# Altium schematic sheet 10_Misc.SchDoc(10_Misc) (continued)

## Library data of the parts
C154 C155 C161-C163 C166 C171 C185 C199 C200 = Cap_0402: Part Description: 0.10µF ±10% 16V X7R Ceramic Capacitor -55°C ~ 125°C Surface Mount, MLCC 0402 (1005 Metric) 0.039" L x 0.020" W (1.00mm x 0.50mm); Manufacturer Part Number 1: GRM155R71C104KA88D; Manufacturer: Murata Electronics; Type: General Purpose MLCC; Tolerance: 10 %; Temperature Coefficient / Code: +/- 15 %; Dielectric: X7R; Case Width: 0.5 mm; Case Length: 1 mm; Capacitance: 0.1 uF; Voltage Rating DC: 16 V; Value: 100nF, 16V; Termination Style: SMD/SMT; Supplier Part Number 1: 81-GRM155R71C104KA88; Supplier 1: Mouser; Standard Pack Qty: 10000; Series: GRM; RoHS - Mouser: Y; RoHS: RoHS Compliant; Reeling: True; Product Category: Low & Medium Capacitance SMD Ceramic Chip Capacitors; Product: General Type MLCCs; Packaging: Reel; Package / Case: 0402 (1005 metric); Operating Temperature Range: - 55 C to + 125 C; Minimum Order Quantity: 1; Minimum Operating Temperature: - 55 C; Maximum Operating Temperature: + 125 C; Manufacturer Part Number: GRM155R71C104KA88D; Component Kind: Standard; Category: Multilayer Ceramic Capacitors MLCC - SMD/SMT; Case Height: 0.5 mm; Case Code - mm: 1005; Case Code - in: 0402; Brand: Murata Electronics; Library Name: LimeMicroAltiumLib_schLib.SchLib
C156 C174 C175 C180 C181 C183 C186 C195 C201 = Cap_0603: Part Description: 0.10µF ±10% 16V X7R Ceramic Capacitor -55°C ~ 125°C Surface Mount, MLCC 0402 (1005 Metric) 0.039" L x 0.020" W (1.00mm x 0.50mm); Manufacturer Part Number 1: GRM155R71C104KA88D; Manufacturer: Murata Electronics; Type: General Purpose MLCC; Tolerance: 10 %; Temperature Coefficient / Code: +/- 15 %; Dielectric: X7R; Case Width: 0.5 mm; Case Length: 1 mm; Capacitance: 0.1 uF; Voltage Rating DC: 16 V; Value: 100nF, 16V; Termination Style: SMD/SMT; Supplier Part Number 1: 81-GRM155R71C104KA88; Supplier 1: Mouser; Standard Pack Qty: 10000; Series: GRM; RoHS - Mouser: Y; RoHS: RoHS Compliant; Reeling: True; Product Category: Low & Medium Capacitance SMD Ceramic Chip Capacitors; Product: General Type MLCCs; Packaging: Reel; Package / Case: 0402 (1005 metric); Operating Temperature Range: - 55 C to + 125 C; Minimum Order Quantity: 1; Minimum Operating Temperature: - 55 C; Maximum Operating Temperature: + 125 C; Component Kind: Standard; Category: Multilayer Ceramic Capacitors MLCC - SMD/SMT; Case Height: 0.5 mm; Case Code - mm: 1005; Case Code - in: 0402; Brand: Murata Electronics; Library Name: LimeFPGAboard_schLib.SchLib
  C156 C174 C175 C180 C181 C195 C201: Manufacturer Part Number: GRM155R71C104KA88D
C157 = Cap_0402: Part Description: CAP CER 0.018UF 16V X7R 0402; Manufacturer Part Number 1: CC0402KRX7R7BB183; Manufacturer: Yageo; Tolerance: ±10%; Temperature Coefficient: X7R; Capacitance: 0.018µF; Value: 18nF, 16V; Thickness (Max): 0.022" (0.55mm); Supplier Part Number 1: 311-1706-1-ND; Supplier 1: Digi-Key; Size / Dimension: 0.039" L x 0.020" W (1.00mm x 0.50mm); RoHS: RoHS Compliant; Part Status: Active; Packaging: Cut Tape (CT); Package / Case: 0402 (1005 Metric); Operating Temperature: -55°C ~ 125°C; Mounting Type: Surface Mount, MLCC; Component Kind: Standard; Category: Capacitors; Applications: General Purpose; Library Name: LimeMicroAltiumLib_schLib.SchLib
C158 C160 = Cap_0402: Part Description: 47pF ±1% 50V C0G, NP0 Ceramic Capacitor -55°C ~ 125°C Surface Mount, MLCC 0402 (1005 Metric) 0.039" L x 0.020" W (1.00mm x 0.50mm); Manufacturer Part Number 1: GRM1555C1H470FA01D; Manufacturer: TDK Corporation; Tolerance: ±1%; Temperature Coefficient: C0G, NP0; Value: 47pF, 50V; Thickness (Max): 0.022" (0.55mm); Supplier Part Number 1: 490-6241-1-ND; Supplier 1: Digi-Key; Size / Dimension: 0.039" L x 0.020" W (1.00mm x 0.50mm); RoHS: RoHS Compliant; Ratings: -; Packaging: Cut Tape (CT); Package / Case: 0402 (1005 Metric); Operating Temperature: -55°C ~ 125°C; Mounting Type: Surface Mount, MLCC; Lead Style: -; Lead Spacing: -; Height - Seated (Max): -; Features: -; Component Kind: Standard; Category: Capacitors; Capacitance: 47pF; Applications: General Purpose; Library Name: LimeMicroAltiumLib_schLib.SchLib
  C160: Voltage - Rated: 50V
C159 C164 C165 C194 = Cap_0402: Part Description: 1 µF ±10% 25V Ceramic Capacitor X5R 0402 (1005 Metric); Manufacturer Part Number 1: C1005X5R1E105K050BC; Manufacturer: TDK; Voltage Rating: 10; Product Range: TDK - C Series; Dielectric Characteristic: X6; Ceramic Capacitor Case: 0402 [1005 Metric]; Capacitance Tolerance: ± 10%; Capacitance: 1; Value: 1uF, 25V; Supplier Part Number 1: 445-9066-1-ND; Supplier 1: Digi-Key; RoHS: YES; MSL: -; Component Kind: Standard; Library Name: LimeMicroAltiumLib_schLib.SchLib
C167-C170 C172 C173 C192 = Cap_0402: Part Description: 100pF ±5% 50V C0G, NP0 Ceramic Capacitor -55°C ~ 125°C Surface Mount, MLCC 0402 (1005 Metric) 0.039" L x 0.020" W (1.00mm x 0.50mm); Manufacturer Part Number 1: CC0402JRNPO9BN101; Manufacturer: Yageo; Tolerance: ±5%; Temperature Coefficient: C0G, NP0; Value: 100pF, 50V; Thickness (Max): 0.022" (0.55mm); Supplier Part Number 1: 311-1024-1-ND; Supplier 1: Digi-Key; Size / Dimension: 0.039" L x 0.020" W (1.00mm x 0.50mm); RoHS: RoHS Compliant; Ratings: -; Packaging: Cut Tape (CT); Package / Case: 0402 (1005 Metric); Operating Temperature: -55°C ~ 125°C; Mounting Type: Surface Mount, MLCC; Lead Style: -; Lead Spacing: -; Height - Seated (Max): -; Features: -; Component Kind: Standard; Category: Capacitors; Capacitance: 100pF; Applications: General Purpose; Library Name: LimeMicroAltiumLib_schLib.SchLib
  C167 C172: Voltage - Rated: 50V
C176 C177 C188 C189 = Cap_0603: Part Description: 22µF ±20% 10V X5R Ceramic Capacitor -55°C ~ 85°C Surface Mount, MLCC 0603 (1608 Metric) 0.063" L x 0.031" W (1.60mm x 0.80mm); Manufacturer Part Number 1: C1608X5R1A226M080AC; Manufacturer: TDK Corporation; Type: Multilayer Ceramic Chip Capacitor; Tolerance: 20 %; Temperature Coefficient / Code: +/- 15 %; Brand: TDK; Voltage Rating DC: 10 V; Value: 22uF, 10V; Supplier Part Number 1: 810-C1608X5R1A226M; Supplier 1: Mouser; Package / Case: 0603 (1608 metric); Operating Temperature Range: - 55 C to + 85 C; Component Kind: Standard; Category: Multilayer Ceramic Capacitors MLCC - SMD/SMT; Case Code - mm: 1608; Case Code - in: 0603; Capacitance: 22 uF; Library Name: LimeFPGAboard_schLib.SchLib
C178 C179 C190 C191 C197 = Cap_0402: Part Description: 4.7µF ±20% 6.3V X5R Ceramic Capacitor -55°C ~ 85°C Surface Mount, MLCC 0402 (1005 Metric) 0.039" L x 0.020" W (1.00mm x 0.50mm); Manufacturer Part Number 1: GRM155R60J475ME87D; Manufacturer: Murata Electronics North America; Tolerance: ±20%; Temperature Coefficient: X5R; Capacitance: 4.7µF; Voltage - Rated: 6.3V; Value: 4.7uF, 6.3V; Thickness (Max): 0.022" (0.55mm); Supplier Part Number 1: 490-5408-1-ND; Supplier 1: Digi-Key; Size / Dimension: 0.039" L x 0.020" W (1.00mm x 0.50mm); RoHS: RoHS Compliant; Ratings: -; Packaging: Cut Tape (CT); Package / Case: 0402 (1005 Metric); Operating Temperature: -55°C ~ 85°C; Mounting Type: Surface Mount, MLCC; Lead Style: -; Lead Spacing: -; Height - Seated (Max): -; Features: Derating Recommended; Component Kind: Standard; Category: Capacitors; Applications: General Purpose; Library Name: LimeMicroAltiumLib_schLib.SchLib
C182 = Cap_0402: Part Description: 16 pF ±5% 50V Ceramic Capacitor C0G, NP0 0402 (1005 Metric); Manufacturer Part Number 1: CC0402JRNPO9BN160; Manufacturer: Yageo; Tolerance: 5%; Dielectric: C0G; Width: 0.02inch; Weight: 2.3E-05oz; Voltage Rating: 50V; Value: 16pF, 50V; Thickness: 0.022inch; Termination: SMD/SMT; Supplier Part Number 1: 311-1662-1-ND; Supplier 1: Digi-Key; Stock 1: 168271; Series: CC; RoHS Compliant: Yes; RoHS: Yes; Resistor Type: Ceramic; Pricing 1: 1=0,10000, 10=0,03700, 50=0,02020, 100=0,01640, 500=0,01174, 1000=0,00922, 2500=0,00838, 5000=0,00771 (USD); Packaging: Tape and Reel; Mount: Surface Mount; Min Operating Temperature: -55°C; Max Operating Temperature: 125°C; Length: 0.039inch; Lead Free: Lead Free; Depth: 0.5mm; ComponentLink2URL: http://www.yageo.com/documents/recent/UPY-GP_NP0_16V-to-50V_16.pdf?src-supplier=Digi-Key; ComponentLink2Description: http://www.yageo.com/documents/recent/UPY-GP_NP0_16V-to-50V_16.pdf?src-supplier=Digi-Key; ComponentLink1URL: http://www.mouser.com/ds/2/447/Yageo_UPY-GP_NP0_16V-to-50V_9-358835.pdf?src-supplier=Mouser; ComponentLink1Description: http://www.mouser.com/ds/2/447/Yageo_UPY-GP_NP0_16V-to-50V_9-358835.pdf?src-supplier=Mouser; Component Kind: Standard; Case Code (Metric): 1005; Case Code (Imperial): 0402; Case/Package: 0402; Capacitance: 16pF; Library Name: LimeMicroAltiumLib_schLib.SchLib
C184 = Cap_0402: Part Description: 1000pF ±10% 50V X7R Ceramic Capacitor -55°C ~ 125°C Surface Mount, MLCC 0402 (1005 Metric) 0.039" L x 0.020" W (1.00mm x 0.50mm); Manufacturer Part Number 1: GCM155R71H102KA37D; Manufacturer: Murata Electronics; Type: Automotive Ultra Small MLCC; Tolerance: 10 %; Temperature Coefficient / Code: +/- 15 %; Dielectric: X7R; Case Width: 0.5 mm; Case Length: 1 mm; Capacitance: 1000 pF; Voltage Rating DC: 50 V; Value: 1nF, 50V; Termination Style: SMD/SMT; Supplier Part Number 1: 81-GCM155R71H102KA7D; Supplier 1: Mouser; Standard Pack Qty: 10000; Series: GCM; RoHS - Mouser: Y; RoHS: RoHS Compliant; Reeling: True; Product Category: Automotive Ceramic Chip Capacitors; Product: Automotive MLCCs; Packaging: Reel; Package / Case: 0402 (1005 metric); Operating Temperature Range: - 55 C to + 125 C; Minimum Order Quantity: 1; Minimum Operating Temperature: - 55 C; Maximum Operating Temperature: + 125 C; Component Kind: Standard; Category: Multilayer Ceramic Capacitors MLCC - SMD/SMT; Case Height: 0.5 mm; Case Code - mm: 1005; Case Code - in: 0402; Brand: Murata Electronics; Library Name: LimeMicroAltiumLib_schLib.SchLib
C187 = Cap_0402: Part Description: 10000pF ±10% 50V Ceramic Capacitor X7R 0402 (1005 Metric); Manufacturer Part Number 1: GRM155R71H103KA88D; Manufacturer: Murata; Voltage: 25V; Tolerance: 10%; Material: Ceramic; Dielectric: X7R; Width: 0.02inch; Voltage Rating (DC): 50V; Voltage Rating: 50V; value: 10nF, 50V; Thickness: 0.022inch; Termination: SMD/SMT; Supplier Part Number 1: 490-4516-1-ND; Supplier 1: Digi-Key; Stock 1: 8941817; RoHS: Yes; REACH SVHC: No SVHC; Radiation Hardening: No; Pricing 1: 1=0,10000, 10=0,03800, 100=0,01690, 500=0,01208, 1000=0,00949, 2500=0,00862, 5000=0,00794 (USD); Packaging: Tape and Reel; Package Quantity: 1; Number of Pins: 2; Mount: Surface Mount; Min Operating Temperature: -55°C; Max Operating Temperature: 125°C; Length: 1mm; Lead Free: Lead Free; Height: 0.5mm; Depth: 0.5mm; Component Kind: Standard; Case Code (Metric): 1005; Case Code (Imperial): 0402; Case/Package: 0402; Capacitance: 10nF; Library Name: LimeFPGAboard_schLib.SchLib
C193 = Cap_0402: Part Description: 82 pF ±5% 50V Ceramic Capacitor CH 0402 (1005 Metric); Manufacturer Part Number 1: UMK105CH820JVHF; Manufacturer: Taiyo Yuden; Value: 82pF, 50V; Supplier Part Number 1: 587-5099-1-ND; Supplier 1: Digi-Key; Stock 1: 10720; RoHS: Yes; Pricing 1: 1=0,10000, 10=0,03000, 50=0,01640, 100=0,01340, 500=0,00954, 1000=0,00750, 2500=0,00682, 5000=0,00627 (USD); Component Kind: Standard; Library Name: LimeMicroAltiumLib_schLib.SchLib
C196 C198 = Cap_0603: Part Description: 10µF ±20% 6.3V X5R Ceramic Capacitor -55°C ~ 85°C Surface Mount, MLCC 0603 (1608 Metric) 0.063" L x 0.031" W (1.60mm x 0.80mm); Manufacturer Part Number 1: CC0603MRX5R5BB106; Manufacturer: Yageo; Tolerance: ±20%; Capacitance: 10µF; Value: 10uF, 6.3V; Supplier Part Number 1: 311-1448-2-ND; Supplier 1: Digi-Key; Package / Case: 0603 (1608 Metric); Component Kind: Standard; Category: Capacitors; Library Name: LimeFPGAboard_schLib.SchLib
ESD4 ESD5 = ESD8472: Part Description: TVS DIODE 5.3VWM 14VC X3DFN2; Manufacturer Part Number 1: ESD8472MUT5G; Manufacturer: ON Semiconductor; Voltage - Reverse Standoff (Typ): 5.3V (Max); Voltage - Clamping (Max) @ Ipp: 14V; Voltage - Breakdown (Min): 7V; Power Line Protection: No; Current - Peak Pulse (10/1000µs): 3A (8/20µs); Capacitance @ Frequency: 0.2pF @ 1MHz; Type: Zener; Supplier Part Number 1: ESD8472MUT5GOSCT-ND; Supplier Device Package: 2-X3DFN (0.62x0.32); Supplier 1: Digi-Key; Stock 1: 2295; RoHS: RoHS Compliant; Pricing 1: 1=0.39, 10=0.315, 100=0.2142, 500=0.16068, 1000=0.12051 (USD); Power - Peak Pulse: -; Part Status: Active; Packaging: Cut Tape (CT); Package / Case: 2-XFDFN; Operating Temperature: -55°C ~ 150°C (TJ); Mounting Type: Surface Mount; ComponentLink1URL: http://www.onsemi.com/pub/Collateral/ESD8472-D.PDF; ComponentLink1Description: http://www.onsemi.com/pub/Collateral/ESD8472-D.PDF; Component Kind: Standard; Category: Circuit Protection; Applications: Automotive, RF Antenna; Library Name: LimeMicroAltiumLib_schLib.SchLib
FR38-FR42 = Ferrite: Part Description: FERRITE BEAD 600 OHM 0201 1LN; Manufacturer Part Number 1: BK0603TS601-T; Manufacturer: Taiyo Yuden; Value: 600R@100MHz; Impedance @ Frequency: 600 Ohm @ 100MHz; Filter Type: Differential Mode - Single; DC Resistance (DCR): 750 mOhm Max; Current Rating: 270mA; Supplier Part Number 1: 587-3277-1-ND; Supplier 1: Digi-Key; Size / Dimension: 0.024" L x 0.012" W (0.60mm x 0.30mm); RoHS: RoHS Compliant; Packaging: Cut Tape (CT); Package / Case: 0201 (0603 Metric); Mounting Type: Surface Mount; Height (Max): 0.013" (0.33mm); Component Kind: Standard; Category: Filters; Library Name: LimeMicroAltiumLib_schLib.SchLib
FR44 FR45 = Ferrite: Part Description: FERRITE BEAD 220 OHM 0402 1LN; Manufacturer Part Number 1: MPZ1005S221ET000; Manufacturer: TDK Corporation; Impedance @ Frequency: 220 Ohm @ 100MHz; DC Resistance (DCR): 220 mOhm Max; Current Rating: 900mA; Value: 220R, 0.9A; Supplier Part Number 1: 445-9329-2-ND; Supplier 1: Digi-Key; Package / Case: 0402 (1005 Metric); Component Kind: Standard; Category: Filters; Library Name: LimeFPGAboard_schLib.SchLib
IC9 = LMK00101: Part Description: Clock Fanout Buffer (Distribution), Multiplexer, Translator IC 200MHz 32-WFQFN Exposed Pad; Manufacturer Part Number 1: LMK00101SQE/NOPB; Manufacturer: Texas Instruments; Voltage - Supply: 2.375 V ~ 3.45 V; Ratio - Input: 3:10; Output: LVCMOS, LVTTL; Number of Circuits: 1; Input: HCSL, LVCMOS, LVDS, LVPECL, LVTTL, SSTL, Crystal; Frequency - Max: 200MHz; Differential - Input: Yes/No; Type: Fanout Buffer (Distribution), Multiplexer, Translator; Supplier Part Number 1: 296-39036-1-ND; Supplier Device Package: 32-WQFN (5x5); Supplier 1: Digi-Key; RoHS: RoHS Compliant; Packaging: Cut Tape (CT); Package / Case: 32-WFQFN Exposed Pad; Operating Temperature: -40°C ~ 85°C; Mounting Type: Surface Mount; Component Kind: Standard; Category: Integrated Circuits (ICs); Library Name: LimeMicroAltiumLib_schLib.SchLib
IC10 = LM75: Part Description: SENSOR TEMPERATURE I2C 8HWSON; Manufacturer Part Number 1: LM75BTP,147; Manufacturer: NXP Semiconductors; Voltage - Supply: 2.8 V ~ 5.5 V; Test Condition: -25°C ~ 100°C (-55°C ~ 125°C); Sensor Type: Digital, Local; Sensing Temperature - Local: -55°C ~ 125°C; Resolution: 10 b; Output Type: I²C; Accuracy - Highest (Lowest): ±2°C (±3°C); Supplier Part Number 1: 568-9815-2-ND; Supplier Device Package: 8-HWSON (2x3); Supplier 1: Digi-Key; Sensing Temperature - Remote: -; RoHS: RoHS Compliant; Packaging: Tape & Reel (TR); Package / Case: 8-WFDFN Exposed Pad; Operating Temperature: -55°C ~ 125°C; Features: Output Switch, Programmable Limit, Shutdown Mode; Component Kind: Standard; Category: Sensors, Transducers; Library Name: LimeMicroAltiumLib_schLib.SchLib
IC11 = AD5601BKSZ-REEL7: Single 8-Bit nanoDAC with SPI Interface in LFCSP and SC70, 2.7 to 5.5 V, <100 uA, -40 to +125 degC, KS-6, Pb-Free, Reel; Part Description: 10 Bit Digital to Analog Converter 1 SC-70-6; Manufacturer Part Number 1: DAC6311IDCKT; Manufacturer: Texas Instruments; Spurious-free dynamic range (SFDR): 65dB; Signal to Noise Ratio (SNR): 81dB; Settling Time: 6us; Sampling Rate: 225KS/s; Resolution: 10b; Polarity: Unipolar; Output Type: Voltage; Operating Supply Voltage: 5V; Number of DAC Channels: 1; Number of Bits: 10; Nominal Supply Current: 110uA; Min Supply Voltage: 1.8V; Max Supply Voltage: 5.5V; Max Power Dissipation: 880uW; Integral Nonlinearity (INL): 0.5LSB; Width: 1.25mm; Termination: SMD/SMT; Supply Type: Single; Supplier Part Number 1: 296-23716-1-ND; Supplier 1: Digi-Key; Stock 1: 13410; RoHS Compliant: Yes; RoHS: Yes; REACH SVHC: No SVHC; Radiation Hardening: No; Pricing 1: 1=2,37000, 10=2,13100, 25=2,01000, 100=1,71250 (USD); Packaging: Tape and Reel; Package Quantity: 1; Number of Pins: 6; Number of D/A Converters: 1; Number of Converters: 1; Number of Channels: 1; Min Operating Temperature: -40°C; Max Operating Temperature: 125°C; Length: 2mm; Lead Free: Lead Free; Interface: SPI; Height: 0.9mm; Differential Nonlinearity: 0.5LSB; Conversion Rate: 225KS/s; Contact Plating: Gold; ComponentLink9URL: https://datasheet.ciiva.com/15146/getdatasheetpartid-213912-15146949.pdf?src-supplier=Verical; ComponentLink9Description: https://datasheet.ciiva.com/15146/getdatasheetpartid-213912-15146949.pdf?src-supplier=Verical; ComponentLink8URL: https://datasheet.ciiva.com/1368/dac5311-1368578.pdf?src-supplier=Digi-Key; ComponentLink8Description: https://datasheet.ciiva.com/1368/dac5311-1368578.pdf?src-supplier=Digi-Key; ComponentLink7URL: https://datasheet.ciiva.com/1368/dac5311-1368577.pdf?src-supplier=Digi-Key; ComponentLink7Description: https://datasheet.ciiva.com/1368/dac5311-1368577.pdf?src-supplier=Digi-Key; ComponentLink6URL: https://datasheet.ciiva.com/46391/1833965-46391478.pdf?src-supplier=Element14; ComponentLink6Description: https://datasheet.ciiva.com/46391/1833965-46391478.pdf?src-supplier=Element14; ComponentLink5URL: https://datasheet.ciiva.com/1503/dac5311-1503186.pdf?src-supplier=Farnell; ComponentLink5Description: https://datasheet.ciiva.com/1503/dac5311-1503186.pdf?src-supplier=Farnell; ComponentLink4URL: https://datasheet.ciiva.com/1494/1833965-1494412.pdf?src-supplier=Element14; ComponentLink4Description: https://datasheet.ciiva.com/1494/1833965-1494412.pdf?src-supplier=Element14; ComponentLink3URL: https://datasheet.ciiva.com/4779/sbas442a-125649-4779152.pdf?src-supplier=Mouser; ComponentLink3Description: https://datasheet.ciiva.com/4779/sbas442a-125649-4779152.pdf?src-supplier=Mouser; ComponentLink2URL: https://datasheet.ciiva.com/1362/dac7311-1362880.pdf?src-supplier=Digi-Key; ComponentLink2Description: https://datasheet.ciiva.com/1362/dac7311-1362880.pdf?src-supplier=Digi-Key; ComponentLink1URL: http://www.ti.com/lit/ds/symlink/dac5311.pdf; ComponentLink1Description: http://www.ti.com/lit/ds/symlink/dac5311.pdf; Component Kind: Standard; Case/Package: SC; Library Name: LimeMicroAltiumLib_schLib.SchLib
IC12 = M24128-DFMC6TG: Part Description: EEPROM Memory IC 128Kb (16K x 8) I²C 1MHz 450ns 8-UFDFPN (2x3); Manufacturer: STMicroelectronics; Write Cycle Time - Word, Page: 5ms; Voltage - Supply: 1.7 V ~ 5.5 V; Memory Type: Non-Volatile; Memory Size: 128Kb (16K x 8); Memory Interface: I²C; Memory Format: EEPROM; Technology: EEPROM; Supplier Part Number 1: 497-15739-1-ND; Supplier Device Package: 8-UFDFPN (2x3); Supplier 1: Digi-Key; Stock 1: 5894; RoHS: RoHS Compliant; Pricing 1: 1=0.35, 10=0.348, 100=0.2854, 500=0.27748, 1000=0.27004 (USD); Part Status: Active; Packaging: Cut Tape (CT); Package / Case: 8-UFDFN Exposed Pad; Operating Temperature: -40°C ~ 85°C (TA); Mounting Type: Surface Mount; Manufacturer Part Number 1: M24128-DFMC6TG; ComponentLink1URL: http://www.st.com/content/ccc/resource/technical/document/datasheet/59/05/c9/5b/7b/41/48/b6/CD00259167.pdf/files/CD00259167.pdf/jcr:content/translations/en.CD00259167.pdf; ComponentLink1Description: http://www.st.com/content/ccc/resource/technical/document/datasheet/59/05/c9/5b/7b/41/48/b6/CD00259167.pdf/files/CD00259167.pdf/jcr:content/translations/en.CD00259167.pdf; Component Kind: Standard; Category: Integrated Circuits (ICs); Library Name: LimeMicroAltiumLib_schLib.SchLib
IC13 IC18 = LD39100PUR: Part Description: Linear Voltage Regulator IC Positive Adjustable Output 0.8 V ~ 4.5 V 1A 6-DFN (3x3); Manufacturer: STMicroelectronics; Voltage Dropout (Max): 0.4V @ 1A; Voltage - Output (Min/Fixed): 0.8V; Voltage - Output (Max): 4.6V; Voltage - Input (Max): 5.5V; PSRR: 70dB ~ 65dB (1kHz ~ 10kHz); Protection Features: Over Current, Over Temperature, Short Circuit; Output Type: Adjustable; Output Configuration: Positive; Current - Supply: 50µA ~ 300µA; Current - Output: 1A; Control Features: Enable, Power Good; Supplier Part Number 1: 497-11013-1-ND; Supplier Device Package: 6-DFN (3x3); Supplier 1: Digi-Key; Stock 1: 27970; RoHS: RoHS Compliant; Pricing 1: 1=0.85, 10=0.76, 100=0.5923, 500=0.48926, 1000=0.38625 (USD); Part Status: Active; Packaging: Cut Tape (CT); Package / Case: 6-VDFN Exposed Pad; Operating Temperature: -40°C ~ 125°C; Mounting Type: Surface Mount; Manufacturer Part Number 1: LD39100PUR; ComponentLink1URL: http://www.st.com/content/ccc/resource/technical/document/datasheet/72/02/e5/ee/df/36/40/5e/CD00233788.pdf/files/CD00233788.pdf/jcr:content/translations/en.CD00233788.pdf; ComponentLink1Description: http://www.st.com/content/ccc/resource/technical/document/datasheet/72/02/e5/ee/df/36/40/5e/CD00233788.pdf/files/CD00233788.pdf/jcr:content/translations/en.CD00233788.pdf; Component Kind: Standard; Category: Integrated Circuits (ICs); Library Name: LimeMicroAltiumLib_schLib.SchLib
IC14 IC19 = LMZ20501SILR_DC-DC: Part Description: Non-Isolated PoL Module DC DC Converter 1 Output 0.8 ~ 3.6V 1A 2.7V - 5.5V Input; Manufacturer: Texas Instruments; Voltage - Output 1: 3.6V; Switching Frequency: 3MHz; Quiescent Current: 72nA; Output Type: Adjustable; Operating Supply Voltage: 5.5V; Number of Outputs: 1; Min Input Voltage: 2.7V; Max Power Dissipation: 4W; Max Output Current: 1A; Efficiency: 93%; Width: 0.14inch; Supplier Part Number 1: 296-40328-1-ND; Supplier 1: Digi-Key; Stock 1: 8106; RoHS Compliant: Yes; RoHS: Yes; Pricing 1: 1=4,48000, 10=4,02000, 25=3,80040, 100=3,29350, 250=3,12464, 500=2,80372, 1000=2,54942 (USD); Packaging: Tape and Reel; Package Quantity: 3000; Output Voltage: 3.6V; Number of Pins: 8; Mount: Surface Mount; Min Operating Temperature: -40°C; Max Operating Temperature: 125°C; Max Input Voltage: 5.5V; Manufacturer Part Number 1: LMZ20501SILR; Length: 0.14inch; Lead Free: Lead Free; Height: 0.07inch; Features: UVLO,OCP,OTP,SCP; ComponentLink4URL: http://www.ti.com/general/docs/lit/getliterature.tsp?genericPartNumber=LMZ20501&&fileType=pdf&src-supplier=Avnet; ComponentLink4Description: http://www.ti.com/general/docs/lit/getliterature.tsp?genericPartNumber=LMZ20501&&fileType=pdf&src-supplier=Avnet; ComponentLink3URL: http://www.ti.com/lit/gpn/lmz20501?src-supplier=Mouser; ComponentLink3Description: http://www.ti.com/lit/gpn/lmz20501?src-supplier=Mouser; ComponentLink2URL: http://www.ti.com/lit/ds/symlink/lmz20501.pdf?src-supplier=Digi-Key; ComponentLink2Description: http://www.ti.com/lit/ds/symlink/lmz20501.pdf?src-supplier=Digi-Key; ComponentLink1URL: http://www.ti.com/lit/pdf/snaa214?src-supplier=Digi-Key; ComponentLink1Description: http://www.ti.com/lit/pdf/snaa214?src-supplier=Digi-Key; Component Kind: Standard; Case/Package: SMD/SMT; Library Name: LimeMicroAltiumLib_schLib_r04.SchLib
IC15 = M25P40_with_pad: Part Description: FLASH - NOR Memory IC 128Mb (16M x 8) SPI - Quad I/O, QPI, DTR 133 MHz 8-SOIC; Manufacturer: Winbond; Memory Type: FLASH,NOR; Interface: SPI,Serial; Frequency: 104MHz; Supplier Part Number 1: W25Q128JVSIQ-ND; Supplier 1: Digi-Key; Stock 1: 7729; RoHS Compliant: Yes; RoHS: Yes; Pricing 1: 1=1,88000, 10=1,71400, 25=1,66760, 50=1,65880, 100=1,48280, 250=1,47852, 500=1,45618, 1000=1,39437, 5000=1,29820 (USD); Packaging: Tray; Min Operating Temperature: -40°C; Max Operating Temperature: 85°C; Manufacturer Part Number 1: W25Q128JVSIQ; ComponentLink1URL: http://www.winbond.com/resource-files/w25q128jv%20revf%2003272018%20plus.pdf?src-supplier=Digi-Key; ComponentLink1Description: http://www.winbond.com/resource-files/w25q128jv%20revf%2003272018%20plus.pdf?src-supplier=Digi-Key; Component Kind: Standard; Case/Package: SOIC; Library Name: LimeMicroAltiumLib_schLib_r03.SchLib
IC16 = AD5662: Part Description: IC DAC 16BIT BUFF V-OUT SOT23-8; Manufacturer Part Number 1: AD5662BRJZ-2REEL7; Manufacturer: Analog Devices Inc.; Voltage - Supply, Digital: 2.7 V ~ 5.5 V; Settling Time: 10µs; Reference Type: External; Output Type: Voltage - Buffered; Number of D/A Converters: 1; Number of Bits: 16; INL/DNL (LSB): ±8, ±1 (Max); Differential Output: No; Data Interface: SPI, DSP; Architecture: String DAC; Voltage - Supply, Analog: 2.7 V ~ 5.5 V; Supplier Part Number 1: AD5662BRJZ-2REEL7CT-ND; Supplier Device Package: SOT-23-8; Supplier 1: Digi-Key; Stock 1: 2784; RoHS: RoHS Compliant; Pricing 1: 1=7.55, 10=6.821, 100=5.6469, 500=4.91722, 1000=4.28274 (USD); Part Status: Active; Packaging: Cut Tape (CT); Package / Case: SOT-23-8; Operating Temperature: -40°C ~ 125°C; ComponentLink1URL: http://www.analog.com/media/en/technical-documentation/data-sheets/AD5662.pdf; ComponentLink1Description: http://www.analog.com/media/en/technical-documentation/data-sheets/AD5662.pdf; Component Kind: Standard; Category: Integrated Circuits (ICs); Library Name: LimeMicroAltiumLib_schLib.SchLib
IC17 = VOLTAGE_REFERENCE_UNIVERSAL: Manufacturer Part Number 1: LM4040C30FTA; Manufacturer: Diodes Inc.; Voltage Tolerance: 0.5%; Temperature Coefficient: 100ppm/°C; Reference Voltage: 3V; Reference Type: Shunt; Output Type: Fixed; Output Current: 15mA; Number of Outputs: 1; Min Output Voltage: 2.985V; Max Output Voltage: 3.015V; Cathode Current: 70µA; Width: 1.3mm; Weight: 7.994566mg; Value: LM4040; Tolerance: 0.5%; Terminal Pitch: 950µm; Temperature Grade: Automotive; Supplier Part Number 1: LM4040C30FTADICT-ND; Supplier 1: DigiKey; Stock 1: 2442; Schedule B: 8542390000, 8542390000|8542390000|8542390000|8542390000|8542390000; RoHS: Compliant; REACH SVHC: Unaffected; Radiation Hardening: No; Pricing 1: 1=0.57, 10=0.403, 25=0.36, 50=0.3342, 100=0.313 (USD); Output Voltage: 3V; Number of Terminals: 3; Number of Pins: 3; Number of Circuits: 1; Number of Channels: 1; Nominal Output Voltage: 3V; Mount: Surface Mount; Min Operating Temperature: -40°C; Max Output Current: 15mA; Max Operating Temperature: 125°C; Lifecycle Status: Production; Length: 2.92mm; Lead Free: Lead Free; Introduction Date: 2008-01-28; Height - Seated (Max): 1.12mm; Height: 1.02mm; Harmonized Tariff Schedule (HTS) Code: 8542.39.00.60; Export Control Classification Number (ECCN) Code: EAR99; Current Rating: 15mA; Country of Origin: Mainland China; ComponentLink6URL: https://datasheet.octopart.com:443/LM4040C30FTA-Diodes-Inc.-datasheet-8325591.pdf?src-supplier=Future+Electronics; ComponentLink6Description: https://datasheet.octopart.com:443/LM4040C30FTA-Diodes-Inc.-datasheet-8325591.pdf?src-supplier=Future+Electronics; ComponentLink5URL: https://sigma.octopart.com:443/138706058/technical_drawing/Diodes-Inc.-LM4040C30FTA.pdf?src-supplier=Diodes+Inc+SCT; ComponentLink5Description: https://sigma.octopart.com:443/138706058/technical_drawing/Diodes-Inc.-LM4040C30FTA.pdf?src-supplier=Diodes+Inc+SCT; ComponentLink4URL: https://datasheet.octopart.com:443/LM4040C30FTA-Diodes-Inc.-datasheet-11728326.pdf?src-supplier=_legacy+Avnet; ComponentLink4Description: https://datasheet.octopart.com:443/LM4040C30FTA-Diodes-Inc.-datasheet-11728326.pdf?src-supplier=_legacy+Avnet; ComponentLink3URL: https://datasheet.octopart.com:443/LM4040C30FTA-Diodes-Inc.-datasheet-79468892.pdf?src-supplier=Augswan; ComponentLink3Description: https://datasheet.octopart.com:443/LM4040C30FTA-Diodes-Inc.-datasheet-79468892.pdf?src-supplier=Augswan; ComponentLink2URL: https://datasheet.octopart.com:443/LM4040C30FTA-Zetex-datasheet-619181.pdf?src-supplier=IHS; ComponentLink2Description: https://datasheet.octopart.com:443/LM4040C30FTA-Zetex-datasheet-619181.pdf?src-supplier=IHS; ComponentLink1URL: https://datasheet.octopart.com:443/LM4040C30FTA-Diodes-Inc.-datasheet-141402645.pdf?src-supplier=IHS; ComponentLink1Description: https://datasheet.octopart.com:443/LM4040C30FTA-Diodes-Inc.-datasheet-141402645.pdf?src-supplier=IHS; Component Kind: Standard; China RoHS: Compliant; Case/Package: TSSOP; Library Name: LimeMicroAltiumLib_schLib_r05.SchLib
IC20 = ATECC508A_authentication_IC: Part Description: Authentication Chip IC Networking and Communications 8-UDFN (2x3); Manufacturer Part Number 1: ATECC508A-MAHDA-S; Manufacturer: Microchip; Supplier Part Number 1: ATECC508A-MAHDA-SDKR-ND; Supplier 1: Digi-Key; Stock 1: 27941; Pricing 1: 1=0.73, 25=0.7064 (USD); Component Kind: Standard; Library Name: LimeMicroAltiumLib_schLib_r01.SchLib
J7 J8 = RF_IF_connector: CONN UMC RCPT STR 50 OHM SMD; Part Description: Ultra Miniature Coaxial Connector Receptacle, Male Pin 50 Ohm Surface Mount Solder; Manufacturer Part Number 1: 0734120110; Manufacturer: Molex, LLC; Voltage Rating: 60V; Shield Termination: Solder; Impedance: 50 Ohm; Frequency - Max: 6GHz; Dielectric Material: Liquid Crystal Polymer (LCP); Connector Type: Jack, Male Pin; Connector Style: Ultra Miniature Coaxial; Center Contact Plating: Gold; Center Contact Material: Brass; Body Material: Phosphor Bronze; Body Finish: Nickel; Supplier Part Number 1: WM5587CT-ND; Supplier 1: Digi-Key; RoHS: RoHS Compliant; Packaging: Cut Tape (CT); Operating Temperature: -40°C ~ 90°C; Mounting Type: Surface Mount; Ingress Protection: -; Housing Color: Silver; Features: -; Fastening Type: Snap-On; Contact Termination: Solder; Component Kind: Standard; Category: Connectors, Interconnects; Cable Group: -; Library Name: LimeMicroAltiumLib_schLib.SchLib
J9 = Jumper: Part Description: 2 Positions Header, Unshrouded Connector 0.100" (2.54mm) Through Hole Gold; Manufacturer Part Number 1: 961102-6404-AR; Manufacturer: 3M; Connector Type: Header, Unshrouded; Supplier Part Number 1: 3M9447-ND; Supplier 1: Digi-Key; Mounting Type: Through Hole; Contact Type: Male Pin; Contact Finish: Gold; Component Kind: Standard; Color: Black; Category: Connectors, Interconnects; Library Name: LimeFPGAboard_schLib.SchLib
LED1-LED3 = LED_green_red_SMD: Part Description: LED GREEN/RED DIFFUSED 0603 SMD; Manufacturer Part Number 1: HSMF-C165; Manufacturer: Avago Technologies US Inc.; Wavelength - Peak: 570nm Green, 636nm Red; Wavelength - Dominant: 572nm Green, 621nm Red; Voltage - Forward (Vf) (Typ): 2.2V Green, 1.95V Red; Viewing Angle: 120°; Millicandela Rating: 15mcd Green, 10mcd Red; Lens Transparency: Diffused; Lens Style/Size: Rectangle with Flat Top, 1.60mm x 0.80mm; Lens Color: White; Current - Test: 20mA; Color: Green, Red; Supplier Part Number 1: 516-1419-1-ND; Supplier 1: Digi-Key; Size / Dimension: 1.60mm L x 0.80mm W; RoHS: RoHS Compliant; Packaging: Cut Tape (CT); Package / Case: 0603 (1608 Metric); Mounting Type: Surface Mount; Height (Max): 0.50mm; Component Kind: Standard; Category: Optoelectronics; Library Name: LimeMicroAltiumLib_schLib.SchLib
R61 R65 R66 R69 R78 R90 R94 R96 R98 R100-R102 = Res_0402: Part Description: RES SMD 0.0OHM JUMPER 1/16W 0402; Manufacturer Part Number 1: RC0402JR-070RL; Manufacturer: Yageo; Tolerance: Jumper; Resistance (Ohms): 0.0; Power (Watts): 0.063W, 1/16W; Value: 0R; Temperature Coefficient: -; Supplier Part Number 1: 311-0.0JRTR-ND; Supplier Device Package: 0402; Supplier 1: Digi-Key; Size / Dimension: 0.039" L x 0.020" W (1.00mm x 0.50mm); RoHS: RoHS Compliant; Packaging: Tape & Reel (TR); Package / Case: 0402 (1005 Metric); Operating Temperature: -55°C ~ 155°C; Number of Terminations: 2; Height: 0.016" (0.40mm); Features: Moisture Resistant; Composition: Thick Film; Component Kind: Standard; Category: Resistors
  R61 R66 R78 R90 R94 R96 R100 R102: Library Name: LimeMicroAltiumLib_schLib.SchLib
  R65 R69 R98 R101: Library Name: LimeFPGAboard_schLib.SchLib
R62 R71 R73 R74 R77 R83 R84 R86 = Res_0402: Part Description: RES SMD 10K OHM 1% 1/16W 0402; Manufacturer Part Number 1: RC0402FR-0710KL; Manufacturer: Yageo; Temperature Coefficient: ±100ppm/°C; Power (Watts): 0.063W, 1/16W; Value: 10k, 1%; Tolerance: ±1%; Supplier Part Number 1: 311-10.0KLRTR-ND; Supplier Device Package: 0402; Supplier 1: Digi-Key; Size / Dimension: 0.039" L x 0.020" W (1.00mm x 0.50mm); RoHS: RoHS Compliant; Resistance (Ohms): 10k; Packaging: Tape & Reel (TR); Package / Case: 0402 (1005 Metric); Operating Temperature: -55°C ~ 155°C; Number of Terminations: 2; Height: 0.016" (0.40mm); Features: Moisture Resistant; Composition: Thick Film; Component Kind: Standard; Category: Resistors; Library Name: LimeMicroAltiumLib_schLib.SchLib
R63 R85 R87 R92 R95 R97 R99 = Res_0402: Part Description: RES SMD 100 OHM 1% 1/16W 0402; Manufacturer Part Number 1: RMCF0402FT100R; Manufacturer: Stackpole Electronics Inc.; Temperature Coefficient: ±100ppm/°C; Resistance (Ohms): 100; Power (Watts): 0.063W, 1/16W; Value: 100R, 1%; Tolerance: ±1%; Supplier Part Number 1: RMCF0402FT100RDKR-ND; Supplier Device Package: 0402; Supplier 1: Digi-Key; Size / Dimension: 0.039" L x 0.020" W (1.00mm x 0.50mm); RoHS: RoHS Compliant; Packaging: Digi-Reel®; Package / Case: 0402 (1005 Metric); Operating Temperature: -55°C ~ 155°C; Number of Terminations: 2; Height: 0.016" (0.40mm); Features: -; Composition: Thick Film; Component Kind: Standard; Category: Resistors; Library Name: LimeMicroAltiumLib_schLib.SchLib
R64 = Res_0402: Part Description: RES SMD 22 OHM 1% 1/16W 0402; Manufacturer Part Number 1: RC0402FR-0722RL; Manufacturer: Yageo; Tolerance: ±1%; Temperature Coefficient: ±100ppm/°C; Resistance (Ohms): 22; Value: 22R; Supplier Part Number 1: 311-22.0LRTR-ND; Supplier Device Package: 0402; Supplier 1: Digi-Key; Composition: Thick Film; Component Kind: Standard; Category: Resistors; Library Name: LimeFPGAboard_schLib.SchLib
R67 R103 = Res_0402: Part Description: RES SMD 49.9 OHM 1% 1/16W 0402; Manufacturer Part Number 1: RC1005F49R9CS; Manufacturer: Samsung Electro-Mechanics America, Inc.; Temperature Coefficient: ±100ppm/°C; Resistance (Ohms): 49.9; Power (Watts): 0.063W, 1/16W; Value: 49.9R, 1%; Tolerance: ±1%; Supplier Part Number 1: 1276-3469-6-ND; Supplier Device Package: 0402 (1005 Metric); Supplier 1: Digi-Key; Size / Dimension: 0.039" L x 0.020" W (1.00mm x 0.50mm); RoHS: RoHS Compliant; Packaging: Digi-Reel®; Package / Case: 0402 (1005 Metric); Operating Temperature: -55°C ~ 155°C; Number of Terminations: 2; Height: 0.016" (0.40mm); Features: -; Composition: Thick Film; Component Kind: Standard; Category: Resistors; Library Name: LimeMicroAltiumLib_schLib.SchLib
R68 R70 R72 R75 R76 R81 = Res_0402: Part Description: RES SMD 1K OHM 1% 1/16W 0402; Manufacturer Part Number 1: RMCF0402FT1K00; Manufacturer: Stackpole Electronics Inc; Temperature Coefficient: ±100ppm/°C; Power (Watts): 0.063W, 1/16W; Value: 1k, 1%; Tolerance: ±1%; Supplier Part Number 1: RMCF0402FT1K00TR-ND; Supplier Device Package: 0402; Supplier 1: Digi-Key; Size / Dimension: 0.039" L x 0.020" W (1.00mm x 0.50mm); RoHS: RoHS Compliant; Resistance (Ohms): 1k; Package / Case: 0402 (1005 Metric); Operating Temperature: -55°C ~ 155°C; Number of Terminations: 2; Height: 0.016" (0.40mm); Composition: Thick Film; Component Kind: Standard; Category: Resistors; Library Name: LimeMicroAltiumLib_schLib.SchLib
  R68 R70 R72 R75 R76: Packaging: Cut Tape (CT); Features: Moisture Resistant
  R81: Packaging: Tape & Reel (TR); Features: -
R79 = Res_0402: Part Description: 80.6 kOhms ±1% 0.063W, 1/16W Chip Resistor 0402 (1005 Metric) Automotive AEC-Q200 Thick Film; Manufacturer Part Number 1: RMCF0402FT80K6; Manufacturer: Stackpole Electronics; Temperature Coefficient: 100ppm/°C; Resistance: 80.6kR; Max Power Dissipation: 63mW; Width: 0.02inch; Value: 80.6k, 1%; Tolerance: 1%; Supplier Part Number 1: RMCF0402FT80K6CT-ND; Supplier 1: Digi-Key; Stock 1: 329079; Series: RMCF; RoHS Compliant: Yes; RoHS: Yes; Resistor Type: Thick Film; Radiation Hardening: No; Pricing 1: 1=0,10000, 10=0,01300, 100=0,00550, 1000=0,00246, 2500=0,00213, 5000=0,00176 (USD); Packaging: Tape and Reel; Number of Terminations: 2; Mount: Surface Mount; Min Operating Temperature: -55°C; Max Operating Temperature: 155°C; Length: 0.039inch; Lead Free: Lead Free; Height: 0.016inch; Features: Automotive AEC-Q200; Contact Plating: Tin,Matte; ComponentLink3URL: https://www.seielect.com/Catalog/SEI-RMCF_RMCP.pdf?src-supplier=Digi-Key; ComponentLink3Description: https://www.seielect.com/Catalog/SEI-RMCF_RMCP.pdf?src-supplier=Digi-Key; ComponentLink2URL: https://www.seielect.com/catalog/SEI-RMCF_RMCP.pdf?src-supplier=Avnet; ComponentLink2Description: https://www.seielect.com/catalog/SEI-RMCF_RMCP.pdf?src-supplier=Avnet; ComponentLink1URL: https://www.seielect.com/catalog/SEI-Packaging.pdf?src-supplier=Digi-Key; ComponentLink1Description: https://www.seielect.com/catalog/SEI-Packaging.pdf?src-supplier=Digi-Key; Component Kind: Standard; Case Code (Metric): 1005; Case Code (Imperial): 0402; Case/Package: 0402; Library Name: LimeMicroAltiumLib_schLib.SchLib
R80 = Res_0402: Part Description: 27 kOhms ±1% 0.063W, 1/16W Chip Resistor 0402 (1005 Metric) Moisture Resistant Thick Film; Manufacturer Part Number 1: RC0402FR-0727KL; Manufacturer: Yageo; Voltage Rating: 50V; Temperature Coefficient: 100ppm/°C; Resistance: 27kR; Power Rating: 63mW; Width: 0.02inch; Value: 27k, 1%; Termination: SMD/SMT; Technology: THICK FILM; Supplier Part Number 1: 311-27.0KLRCT-ND; Supplier 1: Digi-Key; Stock 1: 1102573; Size Code: 0402; Series: RC; RoHS Compliant: Yes; RoHS: Yes; Resistor Type: Thick Film; Resistance Tolerance: 1%; REACH SVHC: Unknown; Pricing 1: 1=0,10000, 10=0,01600, 100=0,00660, 1000=0,00299, 2500=0,00259, 5000=0,00214 (USD); Packaging: Tape and Reel; Package Quantity: 10000; Number of Terminations: 2; Min Operating Temperature: -55°C; Military Standard: Not; Max Power Dissipation: 63mW; Max Operating Temperature: 155°C; Length: 1mm; Lead Free: Lead Free; Height: 0.016inch; Features: Moisture Resistant; Depth: 0.5mm; Construction: Rectangular; ComponentLink1URL: http://www.yageo.com/documents/recent/PYu-RC_Group_51_RoHS_L_7.pdf; ComponentLink1Description: http://www.yageo.com/documents/recent/PYu-RC_Group_51_RoHS_L_7.pdf; Component Kind: Standard; Case Code (Metric): 1005; Case Code (Imperial): 0402; Case/Package: 0402; Library Name: LimeFPGAboard_schLib.SchLib
R82 = Res_0402: Part Description: 40.2 kOhms ±1% 0.063W, 1/16W Chip Resistor 0402 (1005 Metric) Moisture Resistant Thick Film; Manufacturer Part Number 1: RC0402FR-0740K2L; Manufacturer: Yageo; Voltage Rating (DC): 50V; Temperature Coefficient: 100ppm/°C; Resistance: 40.2kR; Power Rating: 62.5mW; Max Power Dissipation: 63mW; Width: 0.02inch; Voltage Rating: 50V; Value: 40.2k, 1%; Tolerance: 1%; Termination: SMD/SMT; Supplier Part Number 1: 311-40.2KLRCT-ND; Supplier 1: Digi-Key; Stock 1: 2895359; Size Code: 0402; Series: RC; RoHS Compliant: Yes; RoHS: Yes; Resistor Type: Thick Film; Pricing 1: 1=0,10000, 10=0,01500, 100=0,00600, 1000=0,00267, 2500=0,00232, 5000=0,00192 (USD); Packaging: Tape and Reel; Package Quantity: 1; Number of Terminations: 2; Min Operating Temperature: -55°C; Military Standard: Not; Max Operating Temperature: 155°C; Length: 0.039inch; Lead Free: Lead Free; Height: 0.016inch; Features: Moisture Resistant; Depth: 0.5mm; Contact Plating: Tin,Matte; Construction: Rectangular; ComponentLink6URL: https://datasheet.ciiva.com/16744/pyu-rc0402-51-rohs-l-6-r-16744286.pdf?src-supplier=Future+Electronics; ComponentLink6Description: https://datasheet.ciiva.com/16744/pyu-rc0402-51-rohs-l-6-r-16744286.pdf?src-supplier=Future+Electronics; ComponentLink5URL: https://datasheet.ciiva.com/8889/pyu-rc0402-51-rohs-l-6-8889263.pdf?src-supplier=Avnet; ComponentLink5Description: https://datasheet.ciiva.com/8889/pyu-rc0402-51-rohs-l-6-8889263.pdf?src-supplier=Avnet; ComponentLink4URL: https://datasheet.ciiva.com/5557/pyu-rc0402-51-rohs-l-6-r-5557981.pdf?src-supplier=Mouser; ComponentLink4Description: https://datasheet.ciiva.com/5557/pyu-rc0402-51-rohs-l-6-r-5557981.pdf?src-supplier=Mouser; ComponentLink3URL: https://datasheet.ciiva.com/2453/pyu-r-int-thick-7-2453436.pdf?src-supplier=Digi-Key; ComponentLink3Description: https://datasheet.ciiva.com/2453/pyu-r-int-thick-7-2453436.pdf?src-supplier=Digi-Key; ComponentLink2URL: https://datasheet.ciiva.com/2453/pyu-r-int-thick-7-2453438.pdf?src-supplier=Digi-Key; ComponentLink2Description: https://datasheet.ciiva.com/2453/pyu-r-int-thick-7-2453438.pdf?src-supplier=Digi-Key; ComponentLink1URL: https://datasheet.ciiva.com/2453/pyu-r-int-thick-7-2453437.pdf?src-supplier=Digi-Key; ComponentLink1Description: https://datasheet.ciiva.com/2453/pyu-r-int-thick-7-2453437.pdf?src-supplier=Digi-Key; Component Kind: Standard; Case Code (Metric): 1005; Case Code (Imperial): 0402; Case/Package: 0402; Library Name: LimeMicroAltiumLib_schLib.SchLib
R88 = Res_0402: Part Description: 237 kOhms ±1% 0.063W, 1/16W Chip Resistor 0402 (1005 Metric) Moisture Resistant Thick Film; Manufacturer Part Number 1: RC0402FR-07237KL; Manufacturer: Yageo; Voltage Rating: 50V; Temperature Coefficient: 100ppm/°C; Resistance: 237kR; Power Rating: 62.5mW; Max Power Dissipation: 63mW; Width: 0.5mm; Value: 237k, 1%; Tolerance: 1%; Termination: SMD/SMT; Supplier Part Number 1: YAG3064CT-ND; Supplier 1: Digi-Key; Stock 1: 306971; Size Code: 0402; Series: RC; RoHS Compliant: Yes; RoHS: Yes; Resistor Type: Thick Film; Pricing 1: 1=0,10000, 10=0,01500, 100=0,00600, 1000=0,00267, 2500=0,00232, 5000=0,00192 (USD); Packaging: Tape and Reel; Number of Terminations: 2; Min Operating Temperature: -55°C; Military Standard: Not; Max Operating Temperature: 155°C; Length: 1mm; Lead Free: Lead Free; Height: 0.016inch; Features: Moisture Resistant; Depth: 0.5mm; Contact Plating: Tin,Matte; Construction: Rectangular; ComponentLink1URL: https://datasheet.ciiva.com/17419/pyu-rc0402-51-rohs-l-6-r-17419479.pdf?src-supplier=Future+Electronics; ComponentLink1Description: https://datasheet.ciiva.com/17419/pyu-rc0402-51-rohs-l-6-r-17419479.pdf?src-supplier=Future+Electronics; Component Kind: Standard; Case Code (Metric): 1005; Case Code (Imperial): 0402; Case/Package: 0402; Library Name: LimeMicroAltiumLib_schLib.SchLib
R89 R93 = Res_0402: Part Description: ±1% 0.063W, 1/16W Chip Resistor 0402 (1005 Metric) Moisture Resistant Thick Film; Manufacturer Part Number 1: RC0402FR-074K7L; Manufacturer: Yageo; Temperature Coefficient: ±100ppm/°C; Power (Watts): 0.063W, 1/16W; Value: 4K7, 1%; Tolerance: ±1%; Supplier Part Number 1: 311-4.7KLRCT-ND; Supplier Device Package: 0402; Supplier 1: Digi-Key; Stock 1: 4788231; Size / Dimension: 0.039" L x 0.020" W (1.00mm x 0.50mm); RoHS: RoHS Compliant; Pricing 1: 1=0.1, 10=0.014, 100=0.0056, 1000=0.00253, 2500=0.0022, 5000=0.00181 (USD); Part Status: Active; Packaging: Cut Tape (CT); Package / Case: 0402 (1005 Metric); Operating Temperature: -55°C ~ 155°C; Height - Seated (Max): 0.016" (0.40mm); Features: Moisture Resistant; Failure Rate: -; Composition: Thick Film; ComponentLink1URL: http://www.yageo.com/documents/recent/PYu-RC_Group_51_RoHS_L_7.pdf; ComponentLink1Description: http://www.yageo.com/documents/recent/PYu-RC_Group_51_RoHS_L_7.pdf; Component Kind: Standard; Category: Resistors; Library Name: LimeFPGAboard_schLib.SchLib
R91 = Res_0402: Part Description: Thick Film Resistors - SMD 0402 52.3Kohms 1% AEC-Q20; Manufacturer Part Number 1: ERJ-2RKF5232X; Manufacturer: Panasonic; Voltage Rating (DC): 50V; Temperature Coefficient: 100ppm/°C; Resistance: 52.3kR; Power Rating: 100mW; Width: 0.5mm; Voltage Rating: 50V; Value: 52.3k, 1%; Tolerance: 1%; Termination: SMD/SMT; Supplier Part Number 1: 667-ERJ-2RKF5232X; Supplier 1: Mouser; Stock 1: 161816; Series: ERJ; RoHS Compliant: Yes; RoHS: Yes; Resistor Type: Thick Film; Resistance Tolerance: 1%; Reach SVHC Compliant: Yes; Ratings: AEC-Q200; Pricing 1: 1=0,10000, 10=0,03400, 50=0,03400, 100=0,01400, 1000=0,00700, 10000=0,00400 (USD); Packaging: Tape and Reel; Package Quantity: 10000; Number of Terminations: 2; Mount: Surface Mount; Min Operating Temperature: -55°C; Military Standard: Not; Max Power Dissipation: 100mW; Max Operating Temperature: 155°C; Length: 1mm; Lead Free: Lead Free; Height: 0.35mm; Features: Automotive AEC-Q200; Depth: 0.5mm; Contact Plating: Tin; Construction: Rectangular; ComponentLink15URL: https://datasheet.ciiva.com/16781/70260748-16781070.pdf?src-supplier=Allied+Electronics; ComponentLink15Description: https://datasheet.ciiva.com/16781/70260748-16781070.pdf?src-supplier=Allied+Electronics; ComponentLink14URL: https://datasheet.ciiva.com/43460/426256-43460332.pdf?src-supplier=Farnell; ComponentLink14Description: https://datasheet.ciiva.com/43460/426256-43460332.pdf?src-supplier=Farnell; ComponentLink13URL: https://datasheet.ciiva.com/46389/426256-46389115.pdf?src-supplier=Element14; ComponentLink13Description: https://datasheet.ciiva.com/46389/426256-46389115.pdf?src-supplier=Element14; ComponentLink12URL: https://datasheet.ciiva.com/9188/aoa0000ce2-9188938.pdf?src-supplier=Avnet; ComponentLink12Description: https://datasheet.ciiva.com/9188/aoa0000ce2-9188938.pdf?src-supplier=Avnet; ComponentLink11URL: https://datasheet.ciiva.com/6201/426256-6201381.pdf?src-supplier=Farnell; ComponentLink11Description: https://datasheet.ciiva.com/6201/426256-6201381.pdf?src-supplier=Farnell; ComponentLink10URL: https://datasheet.ciiva.com/3883/3984280s8821682n1386-3883650.pdf?src-supplier=Arrow+Electronics; ComponentLink10Description: https://datasheet.ciiva.com/3883/3984280s8821682n1386-3883650.pdf?src-supplier=Arrow+Electronics; ComponentLink9URL: https://datasheet.ciiva.com/16741/pyu-rc0402-51-rohs-l-6-r-16741080.pdf?src-supplier=Future+Electronics; ComponentLink9Description: https://datasheet.ciiva.com/16741/pyu-rc0402-51-rohs-l-6-r-16741080.pdf?src-supplier=Future+Electronics; ComponentLink8URL: https://datasheet.ciiva.com/15189/getdatasheetpartid-326416-15189356.pdf?src-supplier=Verical; ComponentLink8Description: https://datasheet.ciiva.com/15189/getdatasheetpartid-326416-15189356.pdf?src-supplier=Verical; ComponentLink7URL: https://datasheet.ciiva.com/7849/1018594-7849836.pdf?src-supplier=Element14; ComponentLink7Description: https://datasheet.ciiva.com/7849/1018594-7849836.pdf?src-supplier=Element14; ComponentLink6URL: https://datasheet.ciiva.com/7849/1018594-7849837.pdf?src-supplier=Element14; ComponentLink6Description: https://datasheet.ciiva.com/7849/1018594-7849837.pdf?src-supplier=Element14; ComponentLink5URL: https://datasheet.ciiva.com/2453/pyu-r-int-thick-7-2453601.pdf?src-supplier=Digi-Key; ComponentLink5Description: https://datasheet.ciiva.com/2453/pyu-r-int-thick-7-2453601.pdf?src-supplier=Digi-Key; ComponentLink4URL: https://datasheet.ciiva.com/2453/pyu-r-int-thick-7-2453603.pdf?src-supplier=Digi-Key; ComponentLink4Description: https://datasheet.ciiva.com/2453/pyu-r-int-thick-7-2453603.pdf?src-supplier=Digi-Key; ComponentLink3URL: https://datasheet.ciiva.com/2453/pyu-r-int-thick-7-2453602.pdf?src-supplier=Digi-Key; ComponentLink3Description: https://datasheet.ciiva.com/2453/pyu-r-int-thick-7-2453602.pdf?src-supplier=Digi-Key; ComponentLink2URL: https://datasheet.ciiva.com/7983/1018594-7983494.pdf?src-supplier=Element14; ComponentLink2Description: https://datasheet.ciiva.com/7983/1018594-7983494.pdf?src-supplier=Element14; ComponentLink1URL: https://datasheet.ciiva.com/6067/1686128-6067913.pdf?src-supplier=Farnell; ComponentLink1Description: https://datasheet.ciiva.com/6067/1686128-6067913.pdf?src-supplier=Farnell; Component Kind: Standard; Case Code (Metric): 1005; Case Code (Imperial): 0402; Case/Package: 0402; Library Name: LimeMicroAltiumLib_schLib.SchLib
SB1-SB4 = SOLDER_BRIDGE_TRIANGULAR: Can be shorted out using solder paste or 0402-1206 resistor; Part Description: RES SMD 0.0OHM JUMPER 1/16W 0402; Manufacturer Part Number 1: RC0402JR-070RL; Manufacturer: Yageo; Value: 0R; Tolerance: Jumper; Resistance (Ohms): 0.0; Power (Watts): 0.063W, 1/16W; Temperature Coefficient: -; Supplier Part Number 1: 311-0.0JRTR-ND; Supplier Device Package: 0402; Supplier 1: Digi-Key; Size / Dimension: 0.039" L x 0.020" W (1.00mm x 0.50mm); RoHS: RoHS Compliant; Packaging: Tape & Reel (TR); Package / Case: 0402 (1005 Metric); Operating Temperature: -55°C ~ 155°C; Number of Terminations: 2; Height: 0.016" (0.40mm); Features: Moisture Resistant; Composition: Thick Film; Component Kind: Standard; Category: Resistors; Library Name: LimeMicroAltiumLib_schLib_r03.SchLib
VT4 = NDS331N: Part Description: N-Channel 20V 6.3A (Ta) 1.3W (Ta) Surface Mount Micro3™/SOT-23; Manufacturer: Infineon Technologies; Vgs(th) (Max) @ Id: 1.1V @ 10µA; Vgs (Max): ±12V; Rds On (Max) @ Id, Vgs: 21 mOhm @ 6.3A, 4.5V; Power Dissipation (Max): 1.3W (Ta); Input Capacitance (Ciss) (Max) @ Vds: 700pF @ 16V; Gate Charge (Qg) (Max) @ Vgs: 8.9nC @ 4.5V; FET Type: N-Channel; Drive Voltage (Max Rds On,  Min Rds On): 2.5V, 4.5V; Current - Continuous Drain (Id) @ 25°C: 6.3A (Ta); Technology: MOSFET (Metal Oxide); Supplier Part Number 1: IRLML6244TRPBFTR-ND; Supplier Device Package: Micro3™/SOT-23; Supplier 1: Digi-Key; Stock 1: 21000; RoHS: RoHS Compliant; Pricing 1: 3000=0.09979 (USD); Part Status: Active; Packaging: Tape & Reel (TR); Package / Case: TO-236-3, SC-59, SOT-23-3; Operating Temperature: -55°C ~ 150°C (TJ); Mounting Type: Surface Mount; Manufacturer Part Number 1: IRLML6244TRPBF; FET Feature: -; Description: NDS331N; ComponentLink1URL: https://www.infineon.com/dgdl/irlml6244pbf.pdf?fileId=5546d462533600a4015356686fed261f; ComponentLink1Description: https://www.infineon.com/dgdl/irlml6244pbf.pdf?fileId=5546d462533600a4015356686fed261f; Component Kind: Standard; Category: Discrete Semiconductor Products; Library Name: LimeFPGAboard_schLib.SchLib
XO1 = T604-040.0M_VCTCXO_ConnorWinfield: Part Description: 40 MHz VCTCXO LVCMOS Oscillator 3.3V Enable/Disable 10-SMD, No Lead; Manufacturer: Connor-Winfield; Rise Time: 8ns; Output Type: LVCMOS; Nominal Supply Voltage (DC): 3.3V; Min Supply Voltage: 3.135V; Max Supply Voltage: 3.465V; Max Supply Current: 6mA; Load Capacitance: 15pF; Frequency Stability: 0.28ppm; Frequency: 40MHz; Width: 5.0038mm; Supplier Part Number 1: CW776CT-ND; Supplier 1: DigiKey; Stock 1: 73; RoHS: Compliant; REACH SVHC: Unaffected; Pricing 1: 1=30,65, 10=27,54 (USD); Packaging: Cut Tape (CT); Number of Terminals: 10; Number of Pins: 10; Mount: Surface Mount; Min Operating Temperature: -40°C; Max Operating Temperature: 85°C; Manufacturer Part Number 1: T604-040.0M; Lifecycle Status: Production; Length: 7.0104mm; Introduction Date: 2008-05-23; Height: 2.3876mm; Fall Time: 8ns; ComponentLink1URL: https://datasheet.octopart.com:443/T604-040.0M-Connor-Winfield-datasheet-180230288.pdf?src-supplier=IHS; ComponentLink1Description: https://datasheet.octopart.com:443/T604-040.0M-Connor-Winfield-datasheet-180230288.pdf?src-supplier=IHS; Component Kind: Standard; Case/Package: SMD/SMT; Library Name: LimeMicroAltiumLib.SchLib
